# Altium schematic sheet RF_Front_End.SchDoc
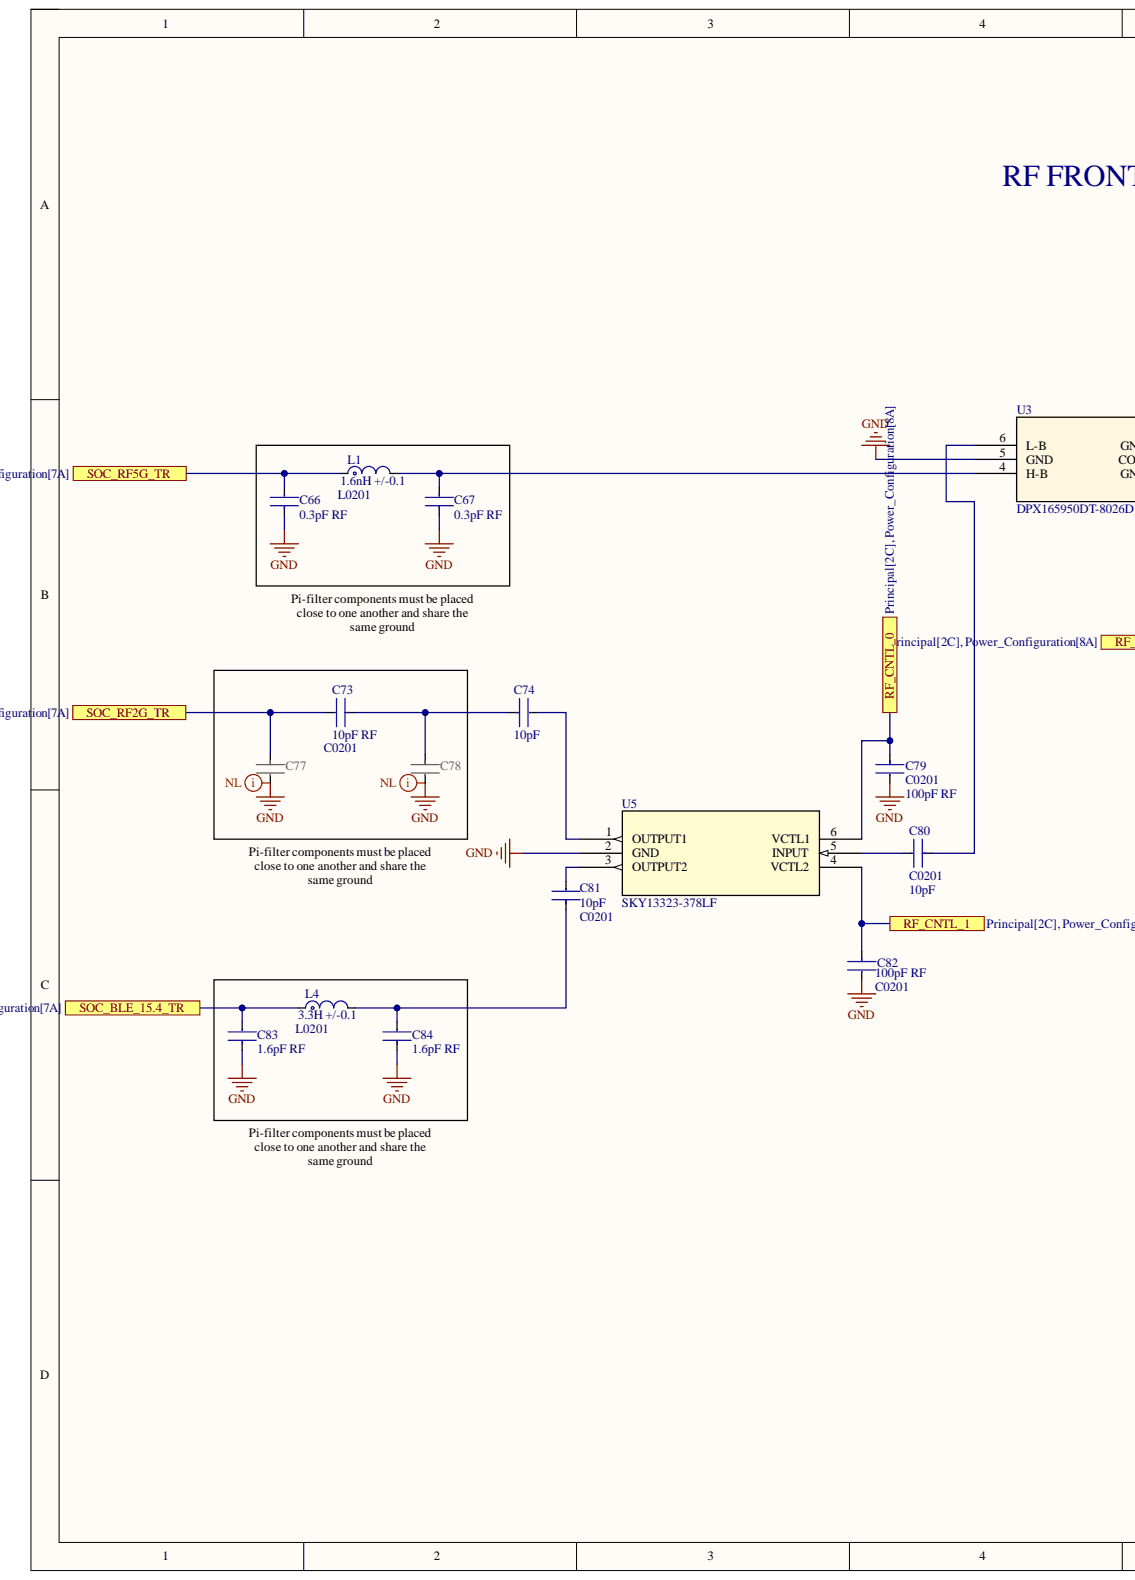
[diagram p0: RF_Front_End.SchDoc, left]
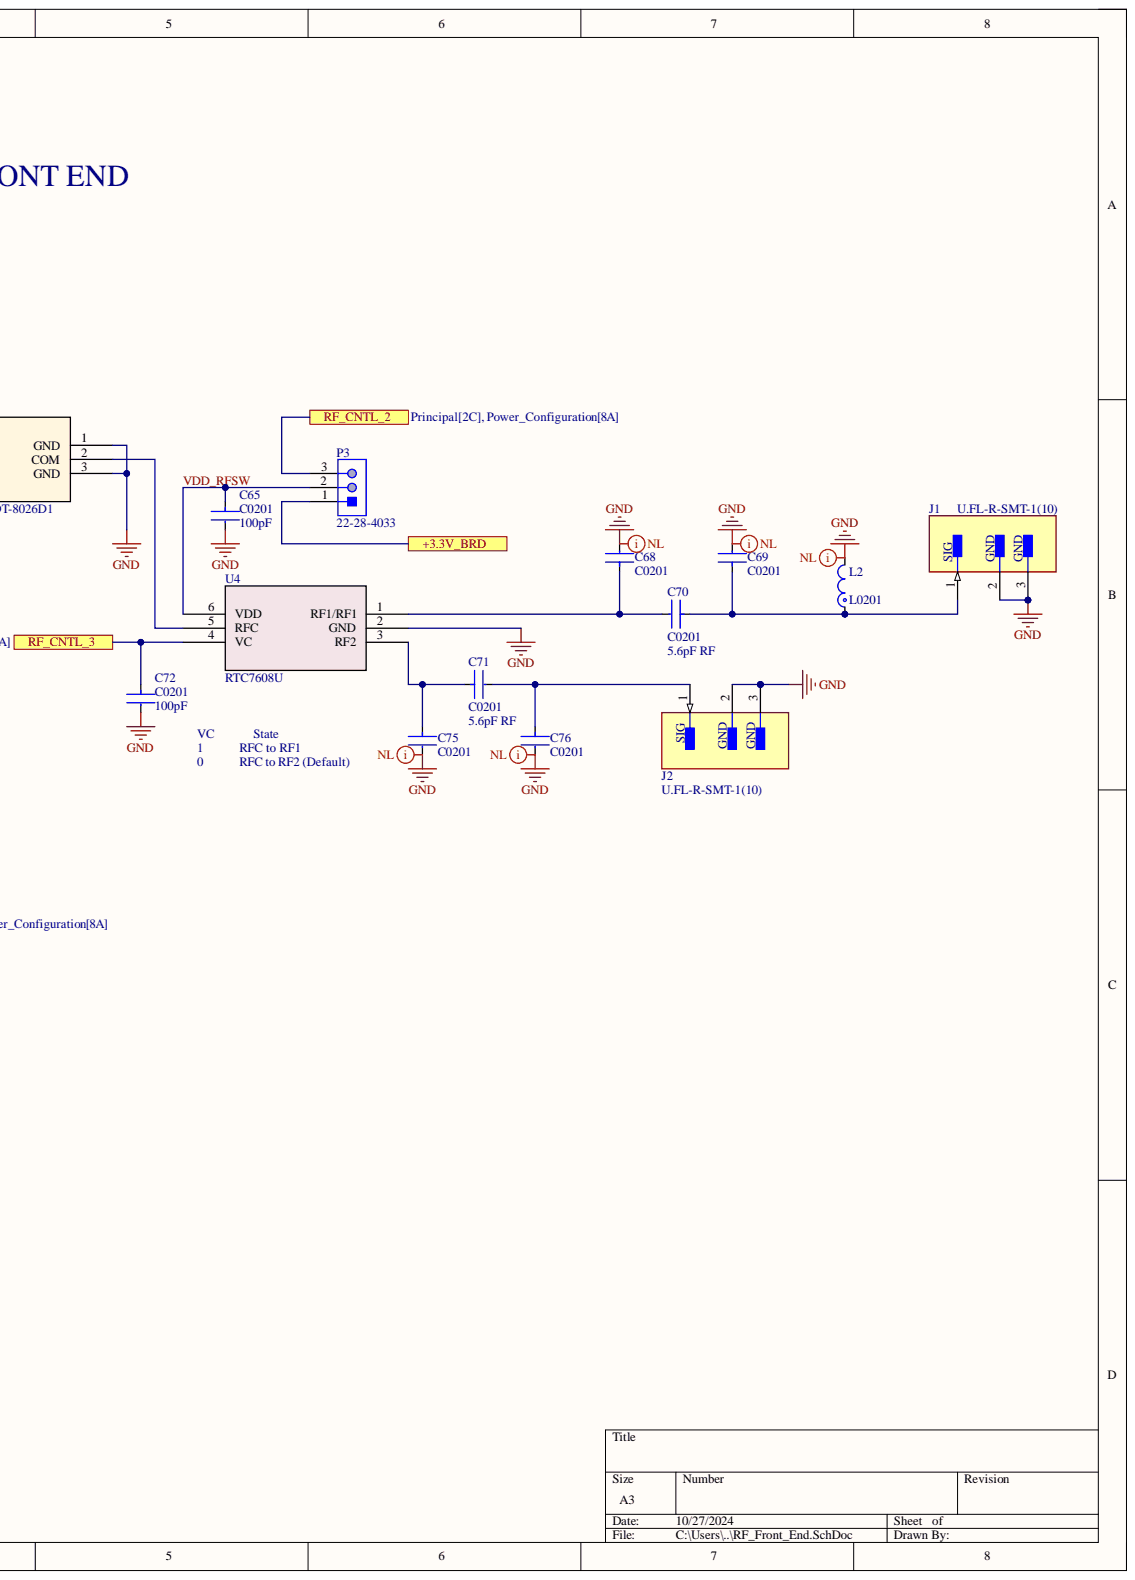
[diagram p1: RF_Front_End.SchDoc, right]

## Parts
C65  100pF  value C0201  [FP-CC0201-BA-MFG]
C66  0.3pF RF  [FP-CC0201-BA-MFG]
C67  0.3pF RF  [FP-CC0201-BA-MFG]
C68  C0201  [FP-CC0201-BA-MFG]
C69  C0201  [FP-CC0201-BA-MFG]
C70  5.6pF RF  value C0201  [FP-CC0201-BA-MFG]
C71  5.6pF RF  value C0201  [FP-CC0201-BA-MFG]
C72  100pF  value C0201  [FP-CC0201-BA-MFG]
C73  10pF RF  value C0201  [FP-CC0201-BA-MFG]
C74  10pF  [FP-CC0201-BA-MFG]
C75  C0201  [FP-CC0201-BA-MFG]
C76  C0201  [FP-CC0201-BA-MFG]
C77  10pF RF  [FP-CC0201-BA-MFG]  (value not drawn)
C78  10pF RF  [FP-CC0201-BA-MFG]  (value not drawn)
C79  100pF RF  value C0201  [FP-CC0201-BA-MFG]
C80  10pF  value C0201  [FP-CC0201-BA-MFG]
C81  10pF  value C0201  [FP-CC0201-BA-MFG]
C82  100pF RF  value C0201  [FP-CC0201-BA-MFG]
C83  1.6pF RF  [FP-CC0201-BA-MFG]
C84  1.6pF RF  [FP-CC0201-BA-MFG]
J1  U.FL-R-SMT-1(10)  [FP-U_FL-R-SMT-1_10-MFG]
J2  U.FL-R-SMT-1(10)  [FP-U_FL-R-SMT-1_10-MFG]
L1  1.6nH +/-0.1  Case Code (Metric): L0201  Inductance: 1.6nH  [FP-LQP03TG_02-IPC_A]
L2  Case Code (Metric): L0201  [FP-LQP03TG_02-IPC_A]
L4  3.3H +/-0.1  Case Code (Metric): L0201  [FP-LQP03TG_02-IPC_A]
P3  22-28-4033  [MOLX-22-28-4033_V]
U3  DPX165950DT-8026D1  [RF_5049A1_TDK]
U4  RTC7608U  [RF_5049A1_TDK]
U5  SKY13323-378LF  [SKY13351-378LF_SKY]

## Ports
+3.3V_BRD, RF_CNTL_0, RF_CNTL_1, RF_CNTL_2, RF_CNTL_3, SOC_BLE_15.4_TR, SOC_RF2G_TR, SOC_RF5G_TR

## Nets
GND: C65.2 C66.1 C67.1 C68.2 C69.2 C72.2 C75.2 C76.2 C77.2 C78.2 C79.2 C82.2 C83.1 C84.1 J1.2 J1.3 J2.2 J2.3 L2.2 U3.1(GND) U3.3(GND) U3.5(GND) U4.2(GND) U5.2(GND)
+3.3V_BRD: P3.1 ; port +3.3V_BRD
RF_CNTL_0: C79.1 U5.6(VCTL1) ; port RF_CNTL_0
RF_CNTL_1: C82.1 U5.4(VCTL2) ; port RF_CNTL_1
RF_CNTL_2: P3.3 ; port RF_CNTL_2
RF_CNTL_3: C72.1 U4.4(VC) ; port RF_CNTL_3
SOC_BLE_15.4_TR: C83.2 L4.1 ; port SOC_BLE_15.4_TR
SOC_RF2G_TR: C73.2 C77.1 ; port SOC_RF2G_TR
SOC_RF5G_TR: C66.2 L1.1 ; port SOC_RF5G_TR
VDD_RFSW: C65.1 P3.2 U4.6(VDD)
NetC67_2: C67.2 L1.2 U3.4(H-B)
NetC68_1: C68.1 C70.2 U4.1(RF1/RF1)
NetC69_1: C69.1 C70.1 J1.1 L2.1
NetC71_1: C71.1 C75.1 U4.3(RF2)
NetC71_2: C71.2 C76.1 J2.1
NetC73_1: C73.1 C74.1 C78.1
NetC74_2: C74.2 U5.1(OUTPUT1)
NetC80_1: C80.1 U3.6(L-B)
NetC80_2: C80.2 U5.5(INPUT)
NetC81_1: C81.1 C84.2 L4.2
NetC81_2: C81.2 U5.3(OUTPUT2)
NetU3_2: U3.2(COM) U4.5(RFC)

## Library data of the parts
C65-C84 = CMP-03422-000622-1: CAP CER 150PF 50V X7R 0201; Manufacturer: Yageo; Manufacturer Part Number: CC0201KRX7R9BB151; Material: Ceramic; Temperature Coefficient: 15%; Tolerance: 0.1; Automotive: No; Capacitance: 150 pF; Category: Cap; Component Kind: Standard; ComponentLink2Description: Datasheet; ComponentLink2URL: http://www.yageo.com/documents/recent/UPY-GPHC_X5R_4V-to-50V_25.pdf; Device Class L1: Passive Components; Device Class L2: Capacitors; Device Class L3: Ceramic Capacitors; Digikey Description: CAP CER 150PF 50V X7R 0201; Footprint Url: http://www.yageo.com/exep/pages/download/literatures/UPY-C_GEN_24.pdf; Height: 0.33mm; Ipc Land Pattern Name: CAPC6030X30; Lead Free: Yes; Library Name: Altium Content Vault; Mouser Description: Multilayer Ceramic Capacitors MLCC - SMD/SMT 150pF 50V X7R 10%; Octopart: https://octopart.com/cc0201krx7r9bb151-yageo-42335970; Package: CC0201-BA; RoHS: TRUE; Temperature: -55°C to +85°C; Temperature Characteristic: X7R; Temperature Range High: +85°C; Temperature Range Low: -55°C; Voltage Rating: 50 V
  C66-C69 C74-C78 C83 C84: Value: 150 pF
J1 J2 = CMP-2000-05152-3: CONN U.FL RCPT STR 50 OHM SMD; Body Material: Bronze; Body Plating: Silver; Connector Type: Coaxial; Dielectric Material: Polymer; Frequency: 6GHz; Gender: Male; Impedance: 50R; Insulation Resistance: 500MR; Component Kind: Standard; Contact Material: Brass; Contact Plating: Gold; Depth: 3.1mm; Fastening Type: Snap; Housing Color: Beige; Lead Free: Lead Free; Length: 3mm; Library Name: Altium Content Vault; Max Frequency: 6GHz; Max Operating Temperature: 90°C; Min Operating Temperature: -40°C; Mount: Surface Mount; Orientation: Straight; Package Quantity: 2500; Packaging: Cut Tape; Radiation Hardening: No; REACH SVHC: No SVHC; Series: U.FL; Termination: Solder; Weight: 0.001764oz; Wire/Cable Type: Coaxial
L1 L2 L4 = CMP-06042-004268-1: Film type RF Inductor 1.6nH ±0.1nH 600mA 0.15Ω 0201 (0603); Core Material: Non-Magnetic; Current: 450mA; DC Resistance (DCR): 150mR; Q Factor: 14; Self Resonant Frequency: 6GHz; Shielding: Unshielded; Test Frequency: 500MHz; Case Code (Imperial): 0201; Case/Package: 0201; Component Kind: Standard; Current Rating: 600mA; DC Current: 600mA; Depth: 0.33mm; Height: 0.012inch; Height - Seated (Max): 0.013inch; Length: 0.024inch; Library Name: Altium Content Vault; Max DC Current: 600mA; Max Operating Temperature: 125°C; Military Standard: Not; Min Operating Temperature: -55°C; Mount: Surface Mount; Package Quantity: 1; Packaging: Tape and Reel; Radiation Hardening: No; Resistor Type: Thin Film; Termination: SMD/SMT; Width: 0.3mm
  L2 L4: Inductance: 1.6nH
P3 = CMP-1676-00001-5: Male Header, Pitch 2.54 mm, 1 x 3 Position, Height 8.38 mm, Tail Length 3.18 mm, RoHS, Bulk; Manufacturer: Molex; Current Rating: 4 A; Rows: 1; Voltage Rating: 250 V; Colour: Black; Component Kind: Standard; ComponentLink1Description: Manufacturer URL; ComponentLink1URL: http://www.molex.com/; ComponentLink2Description: Datasheet; ComponentLink2URL: http://www.molex.com/pdm_docs/sd/022284033_sd.pdf; Contact Finish: Gold; Contact Material: Brass; Contact Plating: Gold; DatasheetVersion: Rev. G, 01/2012; Height: 8.38 mm; Lead Pitch: 0.1 inch; Length: 7.62 mm; Library Name: Altium Content Vault; Max Operating Temperature: 75 degC; Min Operating Temperature: 0 degC; Mount: Through Hole; Mounting Technology: Through Hole; Package Quantity: 1; PackageDescription: Male Header, Pitch 2.54 mm, 1 x 3 Position, Height 8.38 mm, Tail Length 3.18 mm; PackageReference: 22-28-4033; Packaging: Bulk; Pins: 3; REACH SVHC: Unknown; RoHSCompliant: true
U3 = DPX165950DT-8026D1: No Description Available; Mfr_Name: TDK; Component Kind: Standard; Library Name: DPX165950DT-8026D1.IntLib; RefDes: RefDes; Type: Type
U4 = DPX165950DT-8026D1: Cannot found RTC7608U library; Mfr_Name: TDK; Component Kind: Standard; Library Name: DPX165950DT-8026D1.IntLib; RefDes: RefDes; Type: Type
U5 = SKY13323-378LF: No Description Available; Mfr_Name: Skyworks; Component Kind: Standard; Library Name: SKY13323-378LF.IntLib; RefDes: RefDes; Type: Type
